# Altium schematic sheet Gimbal.SchDoc
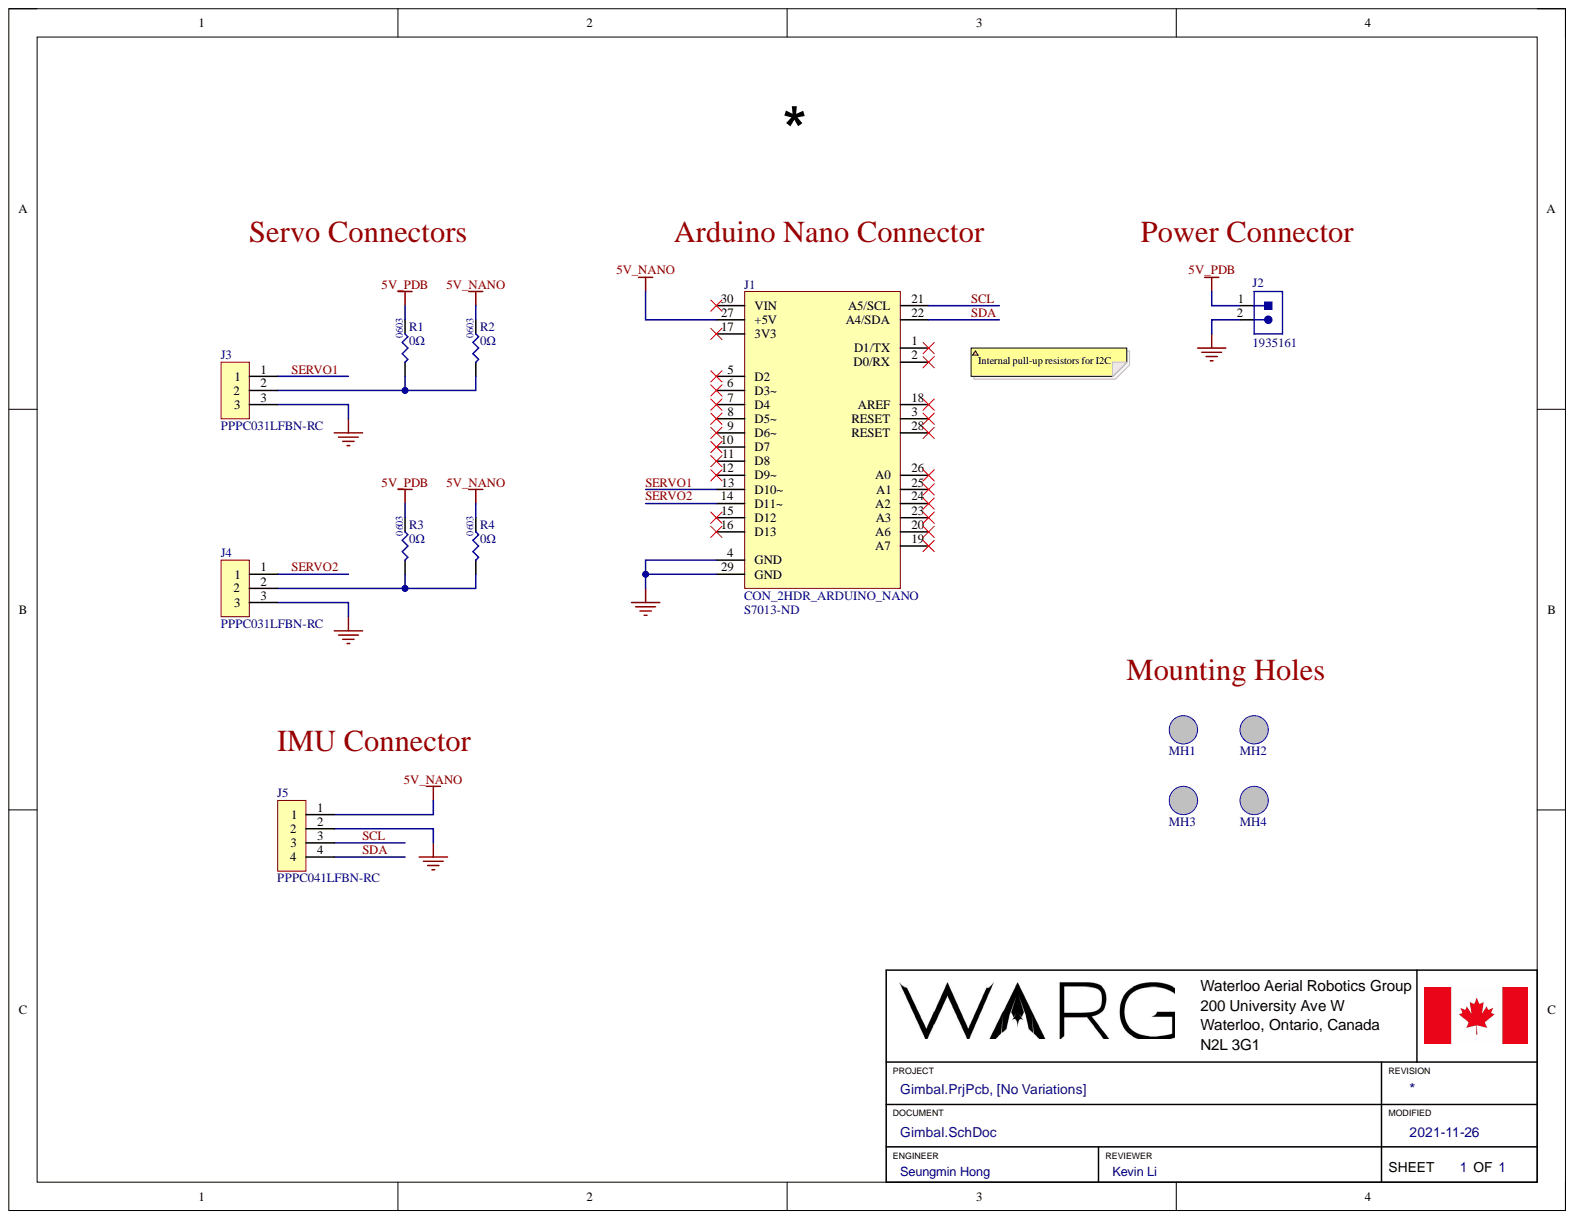
[diagram p0: Gimbal.SchDoc]

## Parts
J1  CON_2HDR_ARDUINO_NANO  Supplier Part Number 1: S7013-ND  [ARDUINO_NANO_CONNECTOR]
J2  CON_2POS_SCREW_TERM  [1935161]  (value not drawn)
J3  CON_3X1_2.54MM_FEMALE_TH_HDR  [PPPC031LFBN-RC]  (value not drawn)
J4  CON_3X1_2.54MM_FEMALE_TH_HDR  [PPPC031LFBN-RC]  (value not drawn)
J5  CON_4X1_2.54MM_FEMALE_TH_HDR  [PPPC041LFBN-RC]  (value not drawn)
MH1  Mounting Hole Non-plated Diameter 2.40mm  [MHNP_2.40MM]  (value not drawn)  (no BOM)
MH2  Mounting Hole Non-plated Diameter 2.40mm  [MHNP_2.40MM]  (value not drawn)  (no BOM)
MH3  Mounting Hole Non-plated Diameter 2.40mm  [MHNP_2.40MM]  (value not drawn)  (no BOM)
MH4  Mounting Hole Non-plated Diameter 2.40mm  [MHNP_2.40MM]  (value not drawn)  (no BOM)
R1  0Ω  [RES0603]
R2  0Ω  [RES0603]
R3  0Ω  [RES0603]
R4  0Ω  [RES0603]

## Nets
5V_NANO: J1.27(+5V) J5.1 R2.2 R4.2
5V_PDB: J2.1 R1.2 R3.2
GND: J1.4(GND) J1.29(GND) J2.2 J3.3 J4.3 J5.2
SCL: J1.21(A5/SCL) J5.3
SDA: J1.22(A4/SDA) J5.4
SERVO1: J1.13(D10~) J3.1
SERVO2: J1.14(D11~) J4.1
NetJ3_2: J3.2 R1.1 R2.1
NetJ4_2: J4.2 R3.1 R4.1

Not connected: J1 1(D1/TX) 2(D0/RX) 3(RESET) 5(D2) 6(D3~) 7(D4) 8(D5~) 9(D6~) 10(D7) 11(D8) 12(D9~) 15(D12) 16(D13) 17(3V3) 18(AREF) 19(A7) 20(A6) 23(A3) 24(A2) 25(A1) 26(A0) 28(RESET) 30(VIN)

## Library data of the parts
J1 = CON_2HDR_ARDUINO_NANO: Arduino Nano mounted on 2x HDR FEMALE 1x15 2.54mm; Manufacturer 1: Sullins Connector Solutions; Manufacturer Part Number 1: PPTC151LFBN-RC; Component Kind: Standard; Pitch: 2.54mm; Supplier 1: Digi-Key; Library Name: WARG.SchLib
J2 = CON_2POS_SCREW_TERM: TERM BLK 2POS SIDE ENTRY 5MM; Manufacturer 1: Phoenix Contact; Component Kind: Standard; Manufacturer Part Number 1: 1935161; Mounting Type: TH; Package: SMD; Supplier 1: Digi-Key; Supplier Part Number 1: 277-1667-ND; Library Name: WARG.SchLib
J3 J4 = CON_3X1_2.54MM_FEMALE_VERT_TH_HDR: CONN HEADER 3X1 2.54MM FEMALE VERT TH; Manufacturer 1: Sullins Connector Solutions; Component Kind: Standard; Manufacturer Part Number 1: PPPC031LFBN-RC; Pitch: 2.54mm; Supplier 1: Digi-Key; Supplier Part Number 1: S7036-ND; Library Name: WARG.SchLib
J5 = CON_4X1_2.54MM_FEMALE_TH_HDR: CONN HEADER 4X1 2.54MM FEMALE VERT TH; Manufacturer 1: Sullins Connector Solutions; Component Kind: Standard; Manufacturer Part Number 1: PPPC041LFBN-RC; Pitch: 2.54mm; Supplier 1: Digi-Key; Supplier Part Number 1: S7037-ND; Library Name: WARG.SchLib
MH1-MH4 = MHNP_2.4MM: Description: Mounting Hole Non-plated Diameter 2.40mm; Diameter: 2.40mm; Library Name: WARG.SchLib
R1-R4 = .RES_0603: GENERIC 0603 RES; Component Kind: Standard; Library Name: WARG.SchLib
